# Altium schematic sheet 05 - Connectors.SchDoc("05 - Connectors")
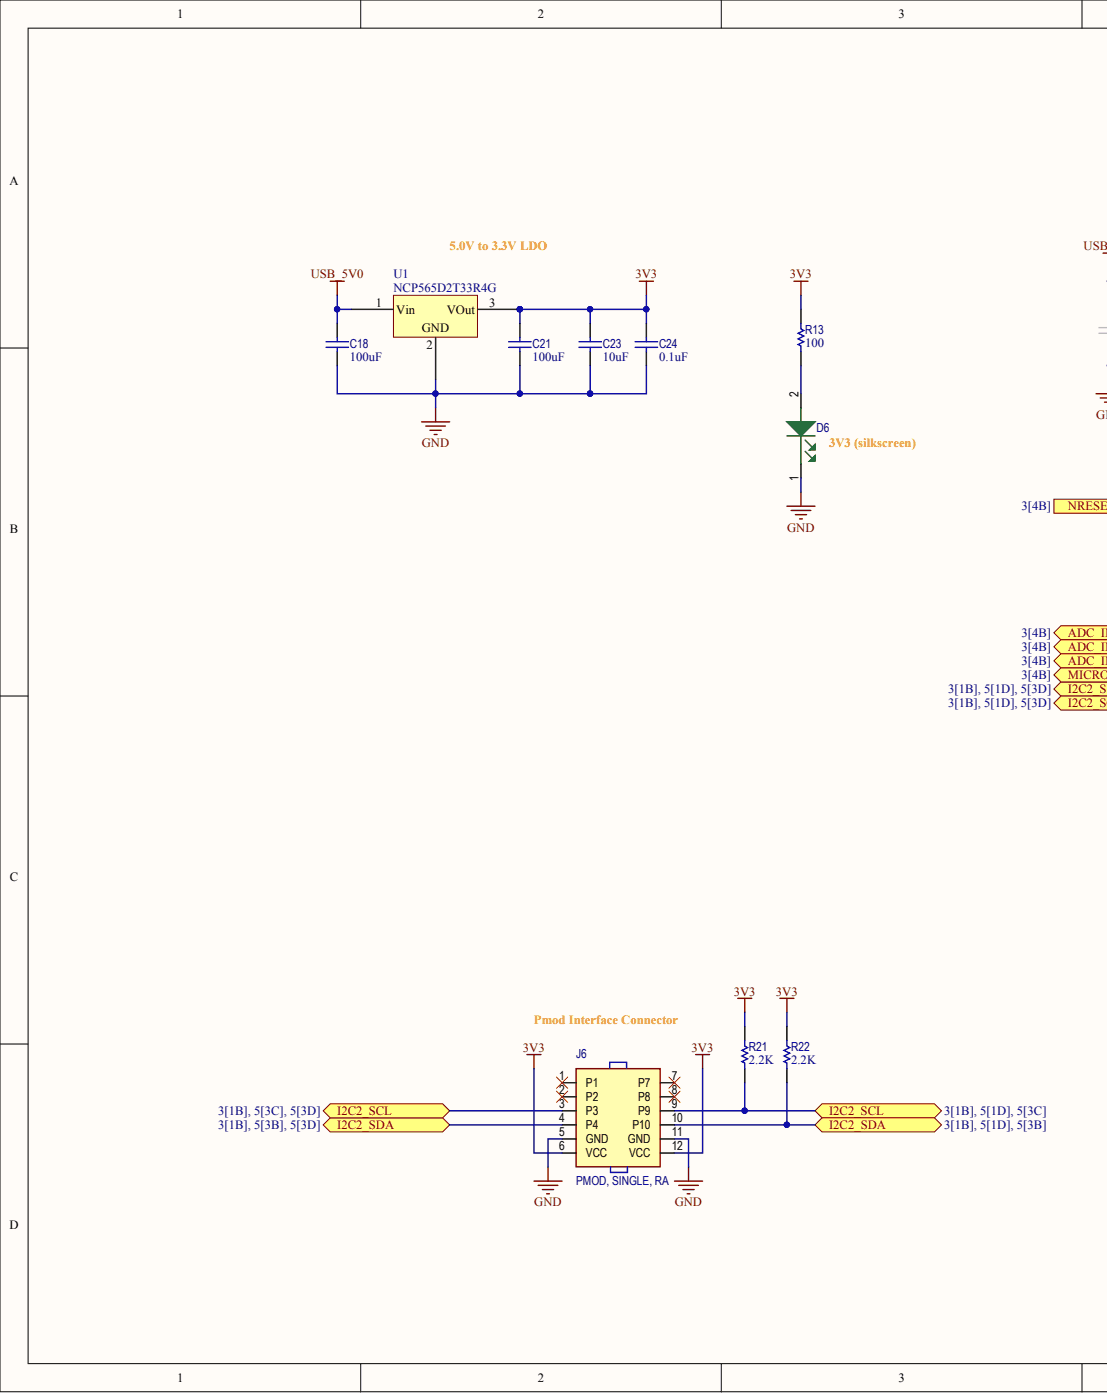
[diagram p0: 05 - Connectors.SchDoc, left]
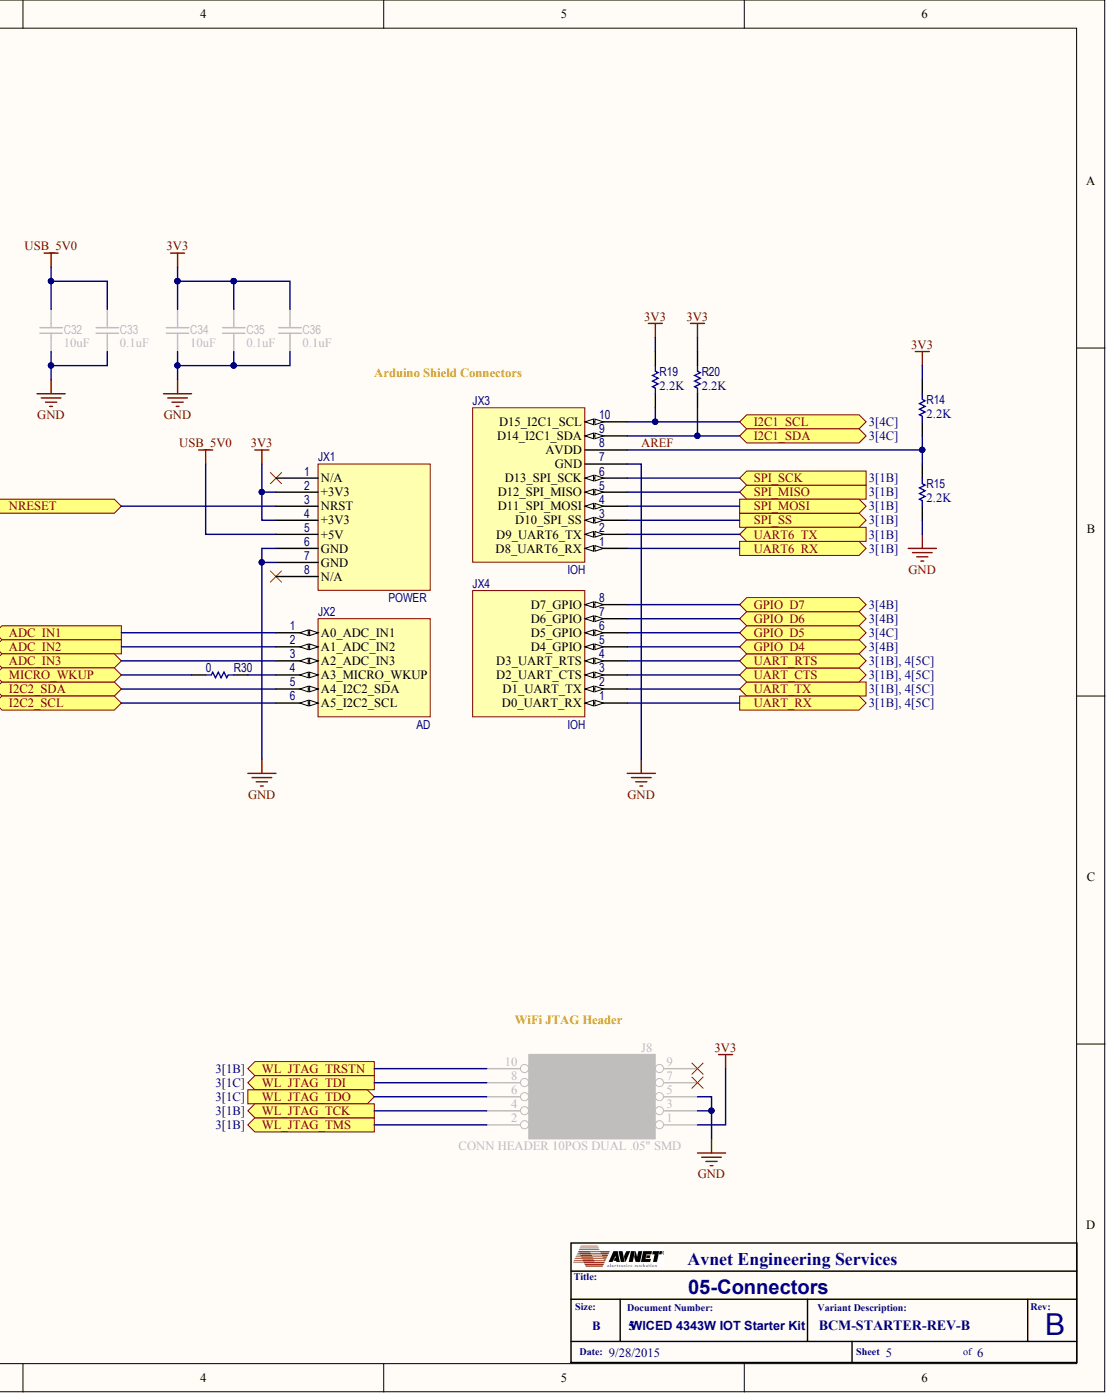
[diagram p1: 05 - Connectors.SchDoc, right]

## Parts
Arduino_R3_(Broadcom_Module)  MFG 1: Arduino  [Arduino R3 (Broadcom)]  (hidden comment: Arduino R3 Shield Symnbol/Footprint (Broadcom))  (no BOM)
C18  100uF  [CAPC1210(3225)630_N]
C21  100uF  [CAPC1210(3225)630_N]
C23  10uF  [CAPC0402(1005)70_N]
C24  0.1uF  [CAPC0402(1005)70_N]
C32  10uF  [CAPC0402(1005)70_N]
C33  0.1uF  [CAPC0402(1005)70_N]
C34  10uF  [CAPC0402(1005)70_N]
C35  0.1uF  [CAPC0402(1005)70_N]
C36  0.1uF  [CAPC0402(1005)70_N]
D6  LED SMARTLED 570NM GREEN 0603  [D0603 (1608 Metric), GREEN]  (value not drawn)
J6  PMOD, SINGLE, RA  [SAMTEC_SSQ-106-02-T-D-RA]
J8  CONN HEADER 10POS DUAL .05" SMD  Material: HEADER  [SAMTEC_FTSH-105-01-L-DV]
R13  100  [RESC0402(1005)_N]
R14  2.2K  [RESC0402(1005)_N]
R15  2.2K  [RESC0402(1005)_N]
R19  2.2K  [RESC0402(1005)_N]
R20  2.2K  [RESC0402(1005)_N]
R21  2.2K  [RESC0402(1005)_N]
R22  2.2K  [RESC0402(1005)_N]
R30  0  [RESC0402(1005)_N]
U1  MFGPN 1: NCP565D2T33R4G  [D2PAK]  (hidden comment: IC REG LDO 3.3V 1.5A D2PAK)

## Ports
ADC_IN1, ADC_IN2, ADC_IN3, GPIO_D4, GPIO_D5, GPIO_D6, GPIO_D7, I2C1_SCL, I2C1_SDA, I2C2_SCL, I2C2_SDA, MICRO_WKUP, NRESET, SPI_MISO, SPI_MOSI, SPI_SCK, SPI_SS, UART6_RX, UART6_TX, UART_CTS, UART_RTS, UART_RX, UART_TX, WL_JTAG_TCK, WL_JTAG_TDI, WL_JTAG_TDO, WL_JTAG_TMS, WL_JTAG_TRSTN

## Nets
3V3: Arduino_R3_(Broadcom_Module).+3V3_1 Arduino_R3_(Broadcom_Module).+3V3_2 C21.2 C23.2 C24.2 C34.2 C35.2 C36.2 J6.6(VCC) J6.12(VCC) J8.1(VTref) R13.2 R14.2 R19.2 R20.2 R21.2 R22.2 U1.3
GND: Arduino_R3_(Broadcom_Module).GND1 Arduino_R3_(Broadcom_Module).GND2 Arduino_R3_(Broadcom_Module).GND3 C18.1 C21.1 C23.1 C24.1 C32.1 C33.1 C34.1 C35.1 C36.1 D6.1 J6.5(GND) J6.11(GND) J8.3(GND) J8.5(GND) R15.1 U1.2
USB_5V0: Arduino_R3_(Broadcom_Module).+5V C18.2 C32.2 C33.2 U1.1
ADC_IN1: Arduino_R3_(Broadcom_Module).A0 ; port ADC_IN1
ADC_IN2: Arduino_R3_(Broadcom_Module).A1 ; port ADC_IN2
ADC_IN3: Arduino_R3_(Broadcom_Module).A2 ; port ADC_IN3
AREF: Arduino_R3_(Broadcom_Module).AVDD R14.1 R15.2
GPIO_D4: Arduino_R3_(Broadcom_Module).D4 ; port GPIO_D4
GPIO_D5: Arduino_R3_(Broadcom_Module).D5 ; port GPIO_D5
GPIO_D6: Arduino_R3_(Broadcom_Module).D6 ; port GPIO_D6
GPIO_D7: Arduino_R3_(Broadcom_Module).D7 ; port GPIO_D7
I2C1_SCL: Arduino_R3_(Broadcom_Module).D15 R19.1 ; port I2C1_SCL
I2C1_SDA: Arduino_R3_(Broadcom_Module).D14 R20.1 ; port I2C1_SDA
I2C2_SCL: Arduino_R3_(Broadcom_Module).A5 J6.3(P3) J6.9(P9) R21.1 ; port I2C2_SCL
I2C2_SDA: Arduino_R3_(Broadcom_Module).A4 J6.4(P4) J6.10(P10) R22.1 ; port I2C2_SDA
MICRO_WKUP: R30.1 ; port MICRO_WKUP
NRESET: Arduino_R3_(Broadcom_Module).NRST ; port NRESET
SPI_MISO: Arduino_R3_(Broadcom_Module).D12 ; port SPI_MISO
SPI_MOSI: Arduino_R3_(Broadcom_Module).D11 ; port SPI_MOSI
SPI_SCK: Arduino_R3_(Broadcom_Module).D13 ; port SPI_SCK
SPI_SS: Arduino_R3_(Broadcom_Module).D10 ; port SPI_SS
UART6_RX: Arduino_R3_(Broadcom_Module).D8 ; port UART6_RX
UART6_TX: Arduino_R3_(Broadcom_Module).D9 ; port UART6_TX
UART_CTS: Arduino_R3_(Broadcom_Module).D2 ; port UART_CTS
UART_RTS: Arduino_R3_(Broadcom_Module).D3 ; port UART_RTS
UART_RX: Arduino_R3_(Broadcom_Module).D0 ; port UART_RX
UART_TX: Arduino_R3_(Broadcom_Module).D1 ; port UART_TX
WL_JTAG_TCK: J8.4(SWCLK/TCK) ; port WL_JTAG_TCK
WL_JTAG_TDI: J8.8(TDI) ; port WL_JTAG_TDI
WL_JTAG_TDO: J8.6(SWO/TDO) ; port WL_JTAG_TDO
WL_JTAG_TMS: J8.2(SWDIO/TMS) ; port WL_JTAG_TMS
WL_JTAG_TRSTN: J8.10(nRESET) ; port WL_JTAG_TRSTN
NetArduino R3 (Broadcom Module)_A3: Arduino_R3_(Broadcom_Module).A3 R30.2
NetD6_2: D6.2 R13.1

Not connected: Arduino_R3_(Broadcom_Module) N/A1 N/A2; J6 1(P1) 2(P2) 7(P7) 8(P8); J8 7(---) 9(NC)

## Library data of the parts
Arduino_R3_(Broadcom_Module) = AVMA-0000012,A-2: AES PN: AVMA-0000012; Component Type: Shield Footprint; Description: Arduino R3 Shield Symnbol/Footprint (Broadcom); Material: Arduino R3; Library Name: AES Altium Vault
C18 C21 = AVPC-0000155,A-1: CAP CER 100UF 16V 20% X5R 1210; Material: CERAMIC; MFG 1: TAIYO YUDEN; MFGPN 1: EMK325ABJ107MM-T; Mount Type (SM/TH): SM; Numeric Value (R=Ω, C=uF, L=uH, FB=Ω ): 100; Polarized (Y/N): N; Preferrence Code: A; Temp-Range: -55 to 85C; AES PN: AVPC-0000155; Component Kind: Standard; Component Type: CAP; Dielectric Type: X5R; MFG 1 Datasheet link: http://www.yuden.co.jp/productdata/catalog/en/mlcc_all_e.pdf; Package: 1210 (3225 Metric); Rated Voltage: 16V; RoHS: Y; Tolerance: 20%; Value: 100uF; Library Name: AES Altium Vault
C23 C32 C34 = AVPC-0000035,A-0: CAP CER 10UF 10V 20% X5R 0402; Material: CERAMIC; MFG 1: SAMSUNG; MFGPN 1: CL05A106MP5NUNC; Mount Type (SM/TH): SM; Numeric Value (R=Ω, C=uF, L=uH, FB=Ω ): 10; Polarized (Y/N): N; Preferrence Code: A; Temp-Range: -55 to 85C; AES PN: AVPC-0000035; Component Kind: Standard; Component Type: CAP; Dielectric Type: X5R; MFG 1 Datasheet link: http://www.samsungsem.com/global/support/library/product-catalog/__icsFiles/afieldfile/2014/11/13/MLCC.pdf; Package: 0402 (1005 Metric); Rated Voltage: 10V; RoHS: Y; Tolerance: 20%; Value: 10uF; Library Name: AES Altium Vault
C24 C33 C35 C36 = AVPC-0000034,A-0: CAP CER 0.1UF 25V 10% X7R 0402; Material: CERAMIC; MFG 1: AVX; MFGPN 1: 04023C104KAT2A; Mount Type (SM/TH): SM; Numeric Value (R=Ω, C=uF, L=uH, FB=Ω ): 0.1; Polarized (Y/N): N; Preferrence Code: A; Temp-Range: -55 to 125C; AES PN: AVPC-0000034; Component Kind: Standard; Component Type: CAP; Dielectric Type: X7R; MFG 1 Datasheet link: http://www.avx.com/docs/Catalogs/cx7r.pdf; Package: 0402 (1005 Metric); Rated Voltage: 25V; RoHS: Y; Tolerance: 10%; Value: 0.1uF; Library Name: BD-BCM-STARTER.SchLib
D6 = AVDL-0000010,A-0: Forward Voltage: 1.7V; Impedance @ Frequency: 525nm; Lense Type: Diffused; MFG 1: OSRAM; MFGPN 1: LG L29K-F2J1-24-Z; Millicandela Rating: 3.5mcd; Mount Type (SM/TH): SM; Preferrence Code: A; Temp-Range: -40 to 85C; Wave-PK: 572nm; AES PN: AVDL-0000010; Color: GREEN; Component Kind: Standard; Component Type: LED; Description: LED SMARTLED 570NM GREEN 0603; MFG 1 Datasheet link: http://www.osram-os.com/Graphics/XPic2/00077107_0.pdf; Package: D0603 (1608 Metric); RoHS: Y; Wavelength: 570nm; Library Name: AES Altium Vault
J6 = AVEC-0000078,A-0: Header TH 100mil 6X2 Right Angle PMOD Horizontal; Header (M/F): F; MFG 1: TE; MFGPN 1: SSQ-106-02-T-D-RA; Number of Positions: 6; Pin Pitch: 0.100(2.54mm); Preferrence Code: A; Rated Current: 5.7A (Per Pin); Rated Voltage: DWV=550V; Shrouded (Y/N): N; Temp-Range: -55 to 105C; AES PN: AVEC-0000078; Color: BLACK; Component Kind: Standard; Component Type: CONNECTOR; Contact Mating Length: 0.145 to .250" (3.68 to 6.35mm; Contact Type: Tin; Fastening Type: -; Features: -; Material: HEADER; MFG 1 Datasheet link: http://www.samtec.com/ftppub/cpdf/SSQ-1XX-XX-XXX-X-XX-XXX-XX-X-MKT.pdf; Mount Type (SM/TH): TH; Number of Pins: 12; Number of Rows: 2; Orientation: RIGHT ANGLE; Package: SSQ-106-02-T-D-RA; RoHS: Y; Row Pitch: 0.100(2.54mm); Library Name: AES Altium Vault
J8 = AVEC-0000077,A-0: Header (M/F): M; MFG 1: SAMTEC; MFGPN 1: FTSH-105-01-L-DV; Mount Type (SM/TH): SM; Number of Positions: 5; Pin Pitch: 0.050(1.27mm); Preferrence Code: A; Rated Current: 3.3A (Per Pin); Schematic Symbol: 10-Pin (5-Position), Male, Dual Row; Shrouded (Y/N): N; Temp-Range: -55 to 125C; AES PN: AVEC-0000077; Color: BLACK; Component Kind: Standard; Component Type: CONNECTOR; Contact Mating Length: 0.120" (3.05mm); Contact Type: Gold, 10µin (0.25µm); Description: CONN HEADER 10POS DUAL .05" SMD; Fastening Type: -; Features: -; MFG 1 Datasheet link: http://www.samtec.com/ftppub/pdf/FTSH_SMT.PDF; Number of Pins: 10; Number of Rows: 2; Orientation: Vertical; RoHS: Y; Row Pitch: 0.050(1.27mm); Library Name: AES Altium Vault
R13 = AVPR-0000783,A-2: RES 100 OHM 0402 1% 1/16W; MFG 1: TBD; Mount Type (SM/TH): SM; Preferrence Code: A; Rated Power: 0.063W; Temp-Range: -55 to 125C; AES PN: AVPR-0000783; Component Kind: Standard; Component Type: RESISTOR; Material: Thick Film; MFG 2: TBD; MFG 3: TBD; MFGPN 1: TBD; MFGPN 2: TBD; MFGPN 3: TBD; Numeric Value (R=Ω, C=uF, L=uH, FB=Ω ): 100; Package: 0402 (1005 Metric); RoHS: Y; Tolerance: 1%; Value: 100; Library Name: AES Altium Vault
R14 R15 R19-R22 = AVPR-0005367,A-0: RES 2.2K OHM 0402 1% 1/16W; MFG 1: TBD; Mount Type (SM/TH): SM; Numeric Value (R=Ω, C=uF, L=uH, FB=Ω ): 2200; Preferrence Code: A; Rated Power: 0.063W; Temp-Range: -55 to 125C; AES PN: AVPR-0005367; Component Kind: Standard; Component Type: RESISTOR; Material: Thick Film; MFG 2: TBD; MFG 3: TBD; MFGPN 1: TBD; MFGPN 2: TBD; MFGPN 3: TBD; Package: 0402 (1005 Metric); RoHS: Y; Tolerance: 1%; Value: 2.2K; Library Name: AES Altium Vault
R30 = AVPR-0000585,A-2: RES 0.0 OHM JUMP 0402 1/16W; MFG 1: TBD; Mount Type (SM/TH): SM; Preferrence Code: A; Rated Power: 0.063W; Temp-Range: -55 to 125C; Tolerance: 1%; AES PN: AVPR-0000585; Component Kind: Standard; Component Type: RESISTOR; Material: Thick Film; MFG 2: TBD; MFG 3: TBD; MFGPN 1: TBD; MFGPN 2: TBD; MFGPN 3: TBD; Numeric Value (R=Ω, C=uF, L=uH, FB=Ω ): 0; Package: 0402 (1005 Metric); RoHS: Y; Value: 0; Library Name: BD-BCM-STARTER.SchLib
U1 = AVIA-0000025,A-0: Input Voltage: Up to 9V; MFG 1: ON SEMI; Mount Type (SM/TH): SM; Number of Outputs: 1; Output Type: Positive Fixed; Preferrence Code: A; Temp-Range: -40 to 125C; AES PN: AVIA-0000025; Applications: LDO; Component Kind: Standard; Component Type: IC; Description: IC REG LDO 3.3V 1.5A D2PAK; Material: GULL; MFG 1 Datasheet link: http://www.onsemi.com/pub_link/Collateral/NCP565-D.PDF; Output Current: 1.5A; Output Voltage: 3.3V; Package: TO-263-3, D²Pak (2 Leads + Tab); RoHS: Y; Library Name: AES Altium Vault
